# Altium schematic sheet mcu_radio.SchDoc
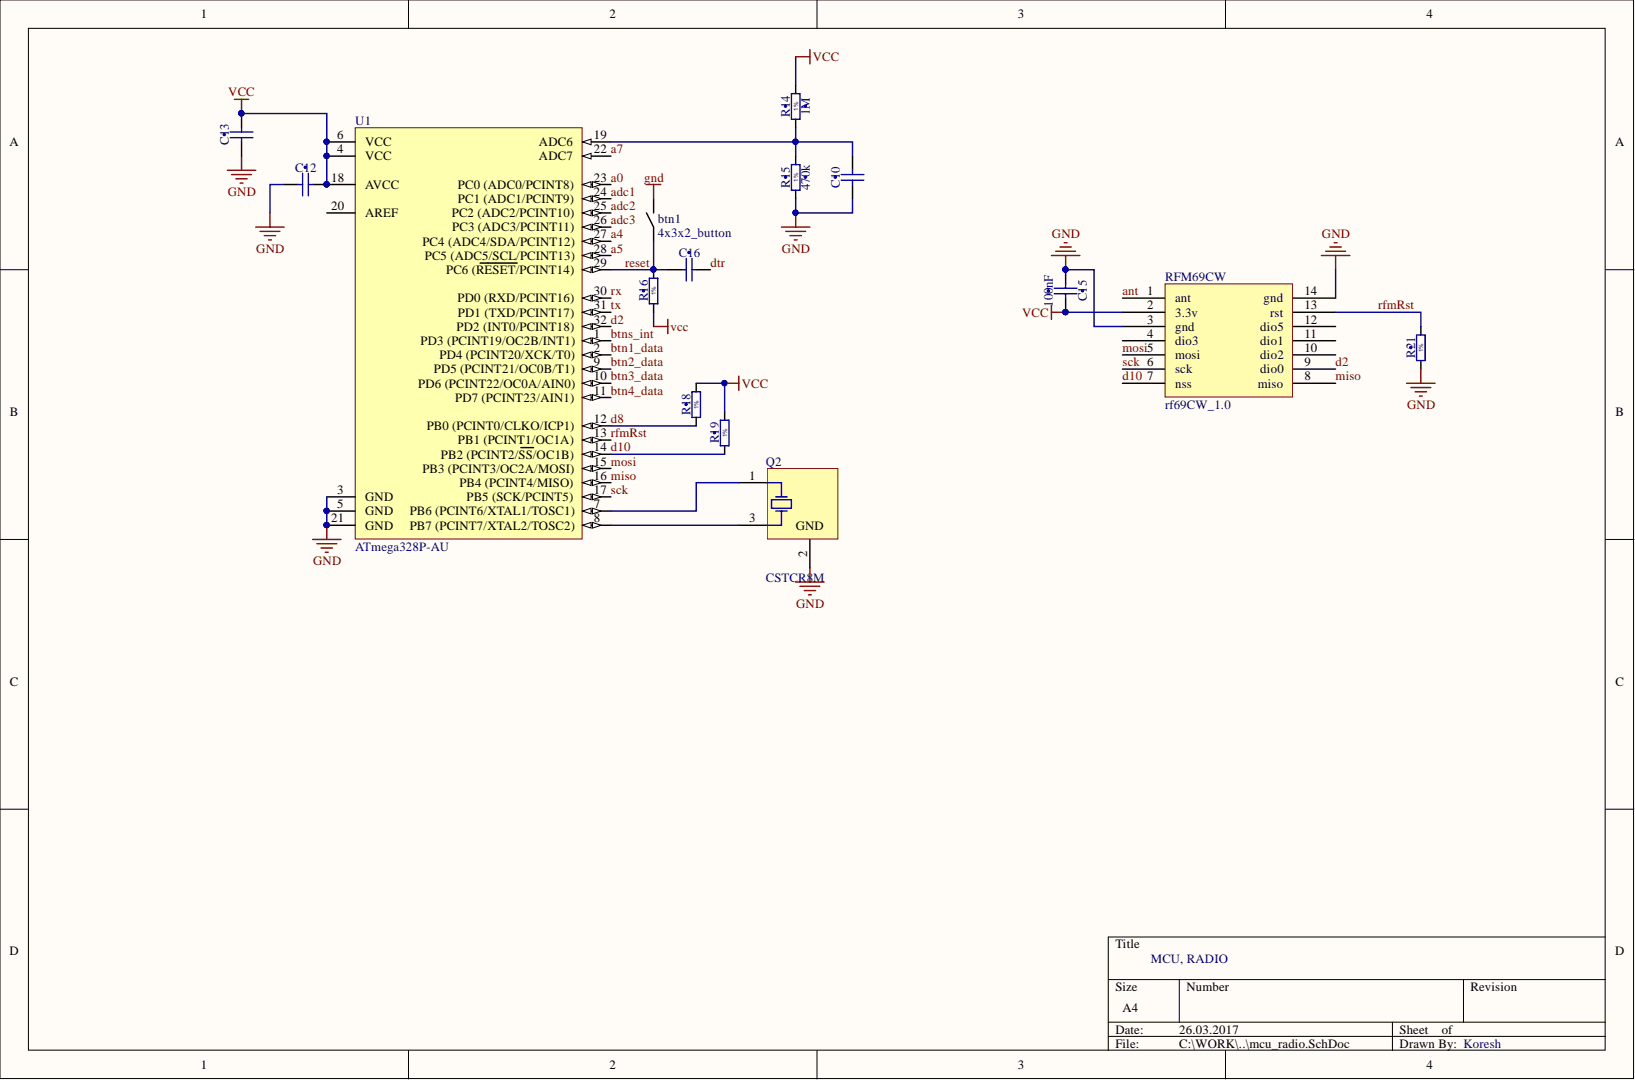
[diagram p0: mcu_radio.SchDoc]

## Parts
btn1  4x3x2_button  [4x3x2_button]
C10  100nF  [CAPC1608X90N]  (value not drawn)
C12  100nF  [CAPC1608X90N]  (value not drawn)
C13  100nF  [CAPC1608X90N]  (value not drawn)
C15  100nF  [CAPC1608X90N]
C16  100nF  [CAPC1608X90N]  (value not drawn)
R14  1M  [RESC1608X55N]
R15  470k  [RESC1608X55N]
R16  10k  [RESC1608X55N]  (value not drawn)
R18  10k  [RESC1608X55N]  (value not drawn)
R19  10k  [RESC1608X55N]  (value not drawn)
R21  10k  [RESC1608X55N]  (value not drawn)
RFM69CW  rf69CW_1.0  [rf69CW_rev1.0_reset]
U1  ATmega328P-AU  [32A_N]

## Nets
GND: btn1.2 C10.1 C12.1 C13.1 C15.1 R15.1 R21.1 RFM69CW.3(gnd) RFM69CW.14(gnd) U1.3(GND) U1.5(GND) U1.21(GND)
VCC: C12.2 C13.2 C15.2 R14.2 R16.1 R18.2 R19.2 RFM69CW.2(3.3v) U1.4(VCC) U1.6(VCC) U1.18(AVCC)
A0: U1.23(PC0_(ADC0/PCINT8))
A4: U1.27(PC4_(ADC4/SDA/PCINT12))
A5: U1.28(PC5_(ADC5/SCL/PCINT13))
A7: U1.22(ADC7)
ADC1: U1.24(PC1_(ADC1/PCINT9))
ADC2: U1.25
ADC3: U1.26(PC3_(ADC3/PCINT11))
ANT: RFM69CW.1(ant)
BTN1_DATA: U1.2(PD4_(PCINT20/XCK/T0))
BTN2_DATA: U1.9(PD5_(PCINT21/OC0B/T1))
BTN3_DATA: U1.10(PD6_(PCINT22/OC0A/AIN0))
BTN4_DATA: U1.11(PD7_(PCINT23/AIN1))
BTNS_INT: U1.1(PD3_(PCINT19/OC2B/INT1))
D2: RFM69CW.9(dio0) U1.32(PD2_(INT0/PCINT18))
D8: R18.1 U1.12(PB0_(PCINT0/CLKO/ICP1))
D10: R19.1 RFM69CW.7(nss) U1.14(PB2_(PCINT2/SS/OC1B))
DTR: C16.2
MISO: RFM69CW.8(miso) U1.16(PB4_(PCINT4/MISO))
MOSI: RFM69CW.5(mosi) U1.15(PB3_(PCINT3/OC2A/MOSI))
RESET: btn1.1 C16.1 R16.2 U1.29(PC6_(RESET/PCINT14))
RFMRST: R21.2 RFM69CW.13(rst) U1.13(PB1_(PCINT1/OC1A))
RX: U1.30(PD0_(RXD/PCINT16))
SCK: RFM69CW.6(sck) U1.17(PB5_(SCK/PCINT5))
TX: U1.31(PD1_(TXD/PCINT17))
NetC10_2: C10.2 R14.1 R15.2 U1.19(ADC6)

Not connected: RFM69CW 4(dio3) 10(dio2) 11(dio1) 12(dio5); U1 7 8 20(AREF)

## Library data of the parts
btn1 = 4x3x2_button: Tact Push Button Switch SMD SMT 3 x 4mm x 2mm; Component Kind: Standard; Library Name: trojan1.SchLib
C10 C12 C13 C15 C16 = CC0603_100NF_100V_10%_X7R: Non-polarised capacitor; Manufacturer: GENERIC; Manufacturer1 Part Number: GRM188R72A104KA35D; Part Description: SMD Multilayer Chip Ceramic Capacitor; Database Table Name: Capacitors SMD; TC: X7R; Tolerance: ±10%; Voltage: 100V; Case: 0603; Component Kind: Standard; Component Type: Standard; CreateDate: 11.12.2013; HelpURL: \\cern.ch\dfs\Applications\Altium\Datasheets\CC0603_MURATA_GRM188R72A104KA35D.pdf; LatestRevisionDate: 11.12.2013; Library Name: Capacitors.DbLib; Manufacturer1 ComponentHeight: 0.9mm; Manufacturer1 Example: MURATA; Manufacturer Part Number: CC0603_100NF_100V_10%_X7R; Mounted: Yes; Part Number: CC0603_100NF_100V_10%_X7R; Pin Count: 2; SMD: Yes; Socket: No; Status: None; Table: Capacitors SMD; Value: 100nF; Author: CERN DEM JLC
R14 = R0603_1M_1%_0.1W_100PPM: Resistor - 1%; Manufacturer: GENERIC; Manufacturer1 Part Number: NRC06F1004TRF; Part Description: General Purpose Thick Film Chip Resistor; Database Table Name: Resistors SMD; Power: 0.1W; TC: ±100ppm/°C; Case: 0603; Component Kind: Standard; Component Type: Standard; CreateDate: 03.12.2007; HelpURL: \\cern.ch\dfs\Applications\Altium\Datasheets\R0603_NIC_NRC.pdf; LatestRevisionDate: 13.03.2008; Library Name: Resistors.DbLib; Manufacturer1 ComponentHeight: 0.55mm; Manufacturer1 Example: NIC COMPONENT; Manufacturer Part Number: R0603_1M_1%_0.1W_100PPM; Mounted: Yes; Part Number: R0603_1M_1%_0.1W_100PPM; Pin Count: 2; SMD: Yes; Socket: No; Status: Preferred; Table: Resistors SMD; Tolerance: ±1%; Value: 1M; Author: CERN DEM JLC
R15 = R0603_470K_1%_0.1W_100PPM: Resistor - 1%; Manufacturer: GENERIC; Manufacturer1 Part Number: NRC06F4703TRF; Part Description: General Purpose Thick Film Chip Resistor; Database Table Name: Resistors SMD; Power: 0.1W; TC: ±100ppm/°C; Case: 0603; Component Kind: Standard; Component Type: Standard; CreateDate: 03.12.2007; HelpURL: \\cern.ch\dfs\Applications\Altium\Datasheets\R0603_NIC_NRC.pdf; LatestRevisionDate: 13.03.2008; Library Name: Resistors.DbLib; Manufacturer1 ComponentHeight: 0.55mm; Manufacturer1 Example: NIC COMPONENT; Manufacturer Part Number: R0603_470K_1%_0.1W_100PPM; Mounted: Yes; Part Number: R0603_470K_1%_0.1W_100PPM; Pin Count: 2; SMD: Yes; Socket: No; Status: Preferred; Table: Resistors SMD; Tolerance: ±1%; Value: 470k; Author: CERN DEM JLC
R16 R18 R19 R21 = R0603_10K_1%_0.1W_100PPM: Resistor - 1%; Manufacturer: GENERIC; Manufacturer1 Part Number: NRC06F1002TRF; Part Description: General Purpose Thick Film Chip Resistor; Database Table Name: Resistors SMD; Power: 0.1W; TC: ±100ppm/°C; Case: 0603; Component Kind: Standard; Component Type: Standard; CreateDate: 03.12.2007; HelpURL: \\cern.ch\dfs\Applications\Altium\Datasheets\R0603_NIC_NRC.pdf; LatestRevisionDate: 13.03.2008; Library Name: Resistors.DbLib; Manufacturer1 ComponentHeight: 0.55mm; Manufacturer1 Example: NIC COMPONENT; Manufacturer Part Number: R0603_10K_1%_0.1W_100PPM; Mounted: Yes; Part Number: R0603_10K_1%_0.1W_100PPM; Pin Count: 2; SMD: Yes; Socket: No; Status: Preferred; Table: Resistors SMD; Tolerance: ±1%; Value: 10k; Author: CERN DEM JLC
U1 = ATmega328P-AU: 8-bit AVR Microcontroller, 32KB Flash, 1KB EEPROM, 2KB SRAM, 32-pin TQFP, Industrial Grade (-40°C to 85°C); Code_JEDEC: MS-026-ABA; Component Kind: Standard; ComponentLink1Description: Manufacturer URL; ComponentLink1URL: http://www.atmel.com/; ComponentLink2Description: ATmega48A/48PA/88A/88PA/168A/168PA/328/328P Datasheet; ComponentLink2URL: http://www.atmel.com/dyn/resources/prod_documents/doc8271.pdf; ComponentLink3Description: ATmega48A/48PA/88A/88PA/168A/168PA/328/328P Summary; ComponentLink3URL: http://www.atmel.com/dyn/resources/prod_documents/8271S.pdf; DatasheetVersion: revD, May-2011; Library Name: Atmel Microcontroller megaAVR.IntLib; PackageDescription: 32-Lead, 7x7x1.0 mm Body, 0.8mm Lead Pitch, Thin Profile Plastic Quad Flat Package (TQFP); PackageReference: 32A; PackageVersion: revC, Oct-2010
RFM69CW = rf69CW_1.0: Component Kind: Standard; Library Name: rf69.SchLib
